# Altium schematic sheet MCU.SchDoc
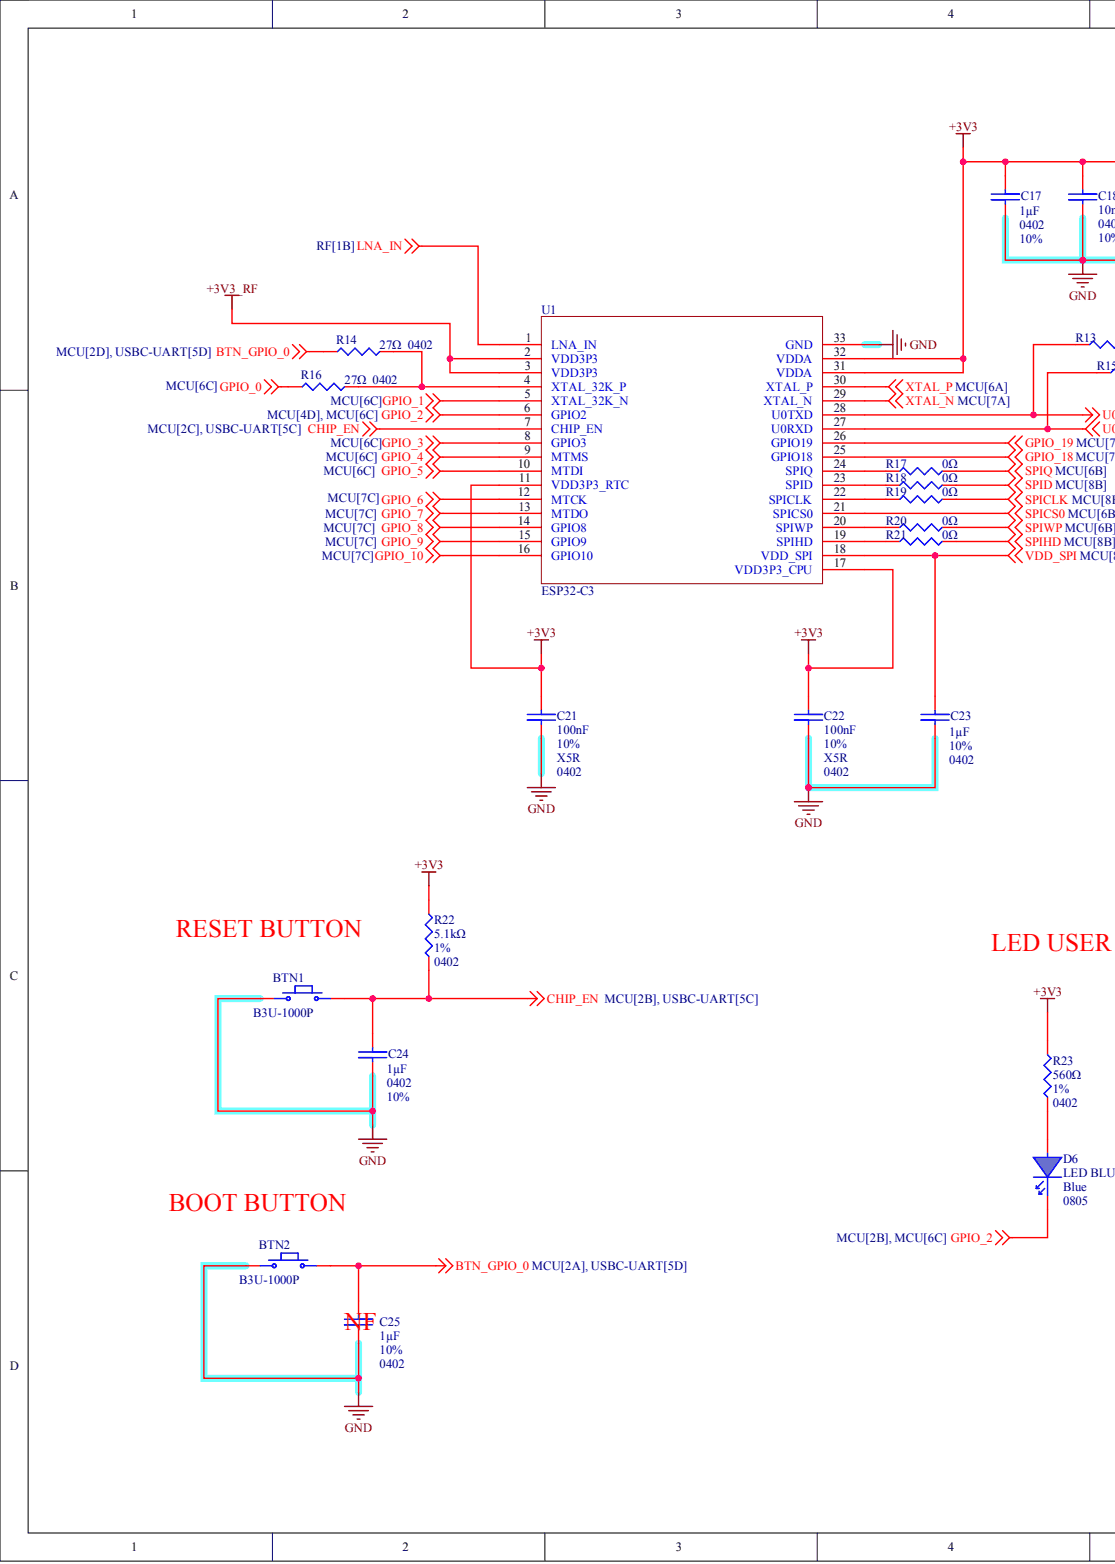
[diagram p0: MCU.SchDoc, left]
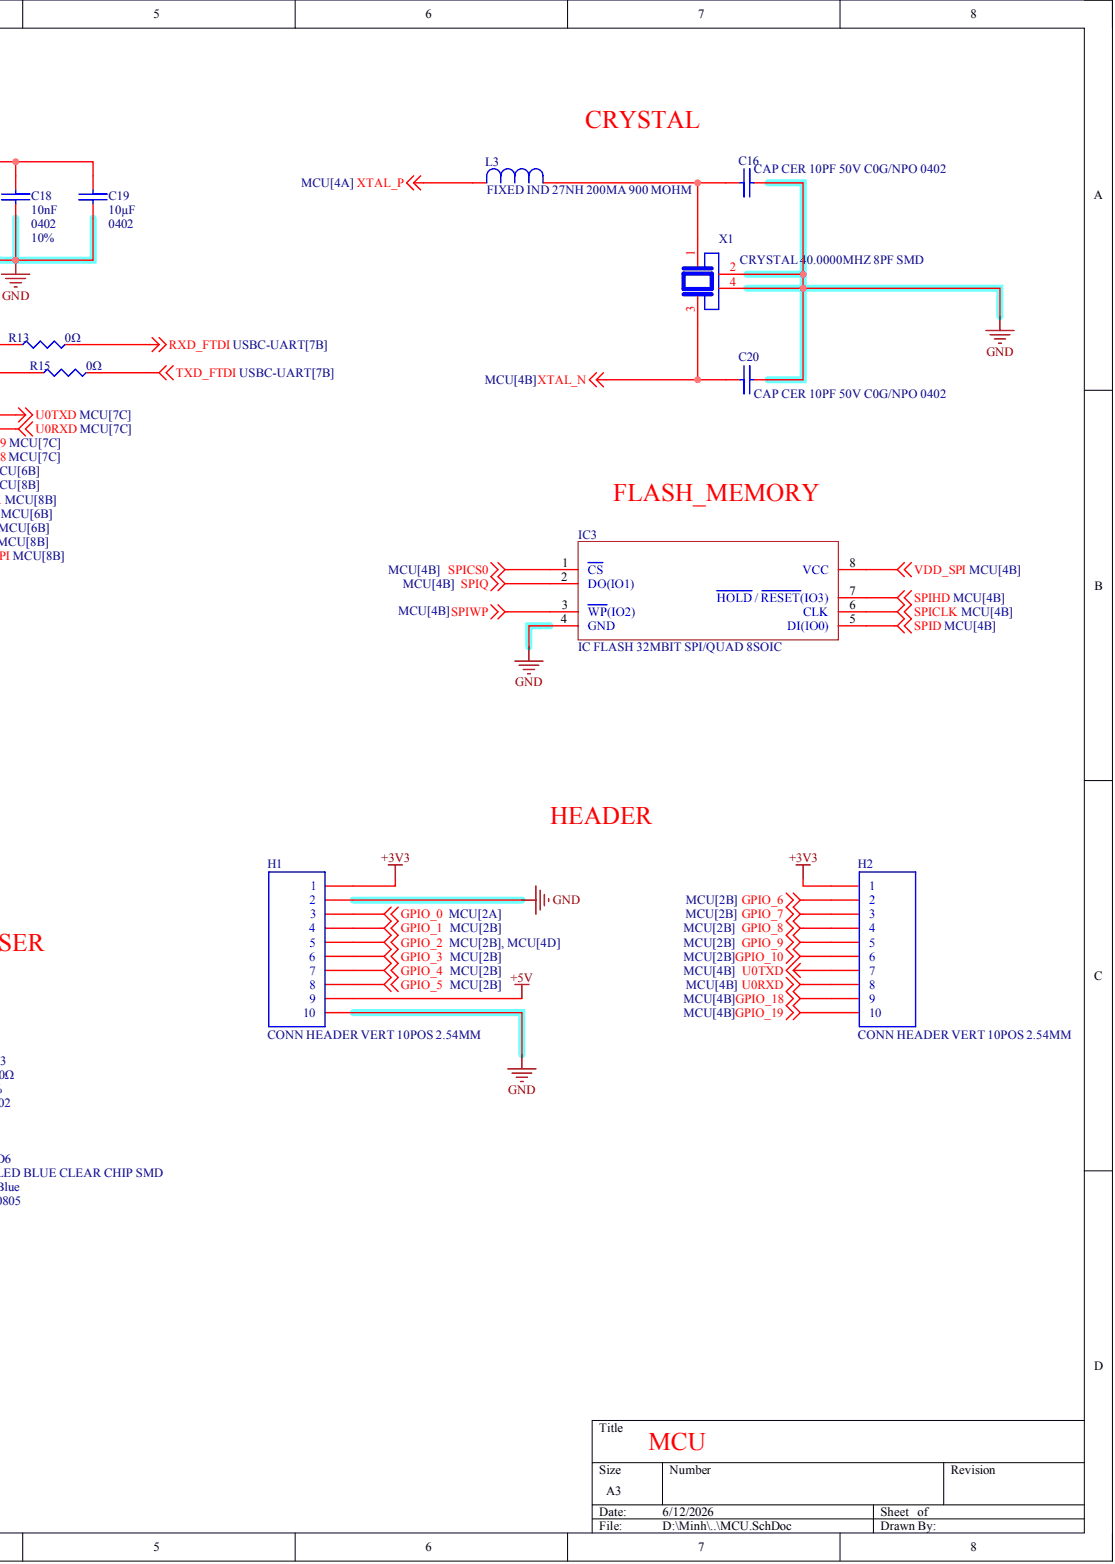
[diagram p1: MCU.SchDoc, right]

## Parts
BTN1  SWITCH TACTILE SPST-NO 0.05A 12V  [SW_B3U-1000P]  (value not drawn)
BTN2  SWITCH TACTILE SPST-NO 0.05A 12V  [SW_B3U-1000P]  (value not drawn)
C16  CAP CER 10PF 50V C0G/NPO 0402  [C0402]
C17  value 1µF  Case Code (Imperial): 0402  Tolerance: 10%  [C0402]  (hidden comment: CAP CER 1UF 10V X5R 0402)
C18  value 10nF  Case Code (Imperial): 0402  Tolerance: 10%  [C0402]  (hidden comment: CAP CER 10000PF 16V X7R 0402)
C19  value 10µF  Case/Package: 0402  [C0402]  (hidden comment: CAP CER 10UF 10V X5R 0402)
C20  CAP CER 10PF 50V C0G/NPO 0402  [C0402]
C21  value 100nF  Case/Package: 0402  Dielectric: X5R  Tolerance: 10%  [C0402]  (hidden comment: CAP CER 100nF 50V X5R 0402)
C22  value 100nF  Case/Package: 0402  Dielectric: X5R  Tolerance: 10%  [C0402]  (hidden comment: CAP CER 100nF 50V X5R 0402)
C23  value 1µF  Case Code (Imperial): 0402  Tolerance: 10%  [C0402]  (hidden comment: CAP CER 1UF 10V X5R 0402)
C24  value 1µF  Case Code (Imperial): 0402  Tolerance: 10%  [C0402]  (hidden comment: CAP CER 1UF 10V X5R 0402)
C25  value 1µF  Case Code (Imperial): 0402  Tolerance: 10%  [C0402]  (hidden comment: CAP CER 1UF 10V X5R 0402)
D6  LED BLUE CLEAR CHIP SMD  Case Code (Imperial): 0805  Color: Blue  [LED_0805_BLUE]
H1  CONN HEADER VERT 10POS 2.54MM  [Header 1*10 2.54mm]
H2  CONN HEADER VERT 10POS 2.54MM  [Header 1*10 2.54mm]
IC3  IC FLASH 32MBIT SPI/QUAD 8SOIC  [SOIC-8_5P28X5P28_WIN-L]
L3  FIXED IND 27NH 200MA 900 MOHM  [IND_L-0402_JOT-L]
R13  value 0Ω  [R0402]  (hidden comment: RES 0 OHM JUMPER 1/16W 0402)
R14  value 27Ω  Case Code (Imperial): 0402  [R0402]  (hidden comment: RES SMD 27 OHM 1% 1/16W 0402)
R15  value 0Ω  [R0402]  (hidden comment: RES 0 OHM JUMPER 1/16W 0402)
R16  value 27Ω  Case Code (Imperial): 0402  [R0402]  (hidden comment: RES SMD 27 OHM 1% 1/16W 0402)
R17  value 0Ω  [R0402]  (hidden comment: RES 0 OHM JUMPER 1/16W 0402)
R18  value 0Ω  [R0402]  (hidden comment: RES 0 OHM JUMPER 1/16W 0402)
R19  value 0Ω  [R0402]  (hidden comment: RES 0 OHM JUMPER 1/16W 0402)
R20  value 0Ω  [R0402]  (hidden comment: RES 0 OHM JUMPER 1/16W 0402)
R21  value 0Ω  [R0402]  (hidden comment: RES 0 OHM JUMPER 1/16W 0402)
R22  value 5.1kΩ  Case Code (Imperial): 0402  Tolerance: 1%  [R0402]  (hidden comment: RES 5.1kΩ ±1% 62.5mW 0402)
R23  value 560Ω  Case Code (Imperial): 0402  Tolerance: 1%  [R0402]  (hidden comment: RES 560 OHM 1% 1/16W 0402)
U1  ESP32-C3  [ESP-C3]
X1  CRYSTAL 40.0000MHZ 8PF SMD  [OSC_XRCGB40M000F1S1BR0]

## Nets
+3V3: C17.1 C18.2 C19.2 C21.2 C22.2 H1.1 H2.1 R22.2 R23.2 U1.11(VDD3P3_RTC) U1.17(VDD3P3_CPU) U1.31(VDDA) U1.32(VDDA)
+3V3_RF: U1.2(VDD3P3) U1.3(VDD3P3)
+5V: H1.9
BTN_GPIO_0: BTN2.2 C25.2 R14.1
CHIP_EN: BTN1.2 C24.2 R22.1 U1.7(CHIP_EN)
GND: BTN1.1 BTN2.1 C16.1 C17.2 C18.1 C19.1 C20.1 C21.1 C22.1 C23.1 C24.1 C25.1 H1.2 H1.10 IC3.4(GND) U1.33(GND) X1.2 X1.4
GPIO_0: H1.3 R16.1
GPIO_1: H1.4 U1.5(XTAL_32K_N)
GPIO_2: D6.1 H1.5 U1.6(GPIO2)
GPIO_3: H1.6 U1.8(GPIO3)
GPIO_4: H1.7 U1.9(MTMS)
GPIO_5: H1.8 U1.10(MTDI)
GPIO_6: H2.2 U1.12(MTCK)
GPIO_7: H2.3 U1.13(MTDO)
GPIO_8: H2.4 U1.14(GPIO8)
GPIO_9: H2.5 U1.15(GPIO9)
GPIO_10: H2.6 U1.16(GPIO10)
GPIO_18: H2.9 U1.25(GPIO18)
GPIO_19: H2.10 U1.26(GPIO19)
LNA_IN: U1.1(LNA_IN)
RXD_FTDI: R13.2
SPICLK: IC3.6(CLK) R19.2
SPICS0: IC3.1(CS) U1.21(SPICS0)
SPID: IC3.5(DI(IO0)) R18.2
SPIHD: IC3.7(HOLD_/_RESET(IO3)) R21.2
SPIQ: IC3.2(DO(IO1)) R17.2
SPIWP: IC3.3(WP(IO2)) R20.2
TXD_FTDI: R15.2
U0RXD: H2.8 R15.1 U1.27(U0RXD)
U0TXD: H2.7 R13.1 U1.28(U0TXD)
VDD_SPI: C23.2 IC3.8(VCC) U1.18(VDD_SPI)
XTAL_N: C20.2 U1.29(XTAL_N) X1.3
XTAL_P: L3.2 U1.30(XTAL_P)
NetC16_2: C16.2 L3.1 X1.1
NetD6_2: D6.2 R23.1
NetR14_2: R14.2 R16.2 U1.4(XTAL_32K_P)
NetR17_1: R17.1 U1.24(SPIQ)
NetR18_1: R18.1 U1.23(SPID)
NetR19_1: R19.1 U1.22(SPICLK)
NetR20_1: R20.1 U1.20(SPIWP)
NetR21_1: R21.1 U1.19(SPIHD)
